# Altium schematic sheet Power.SchDoc
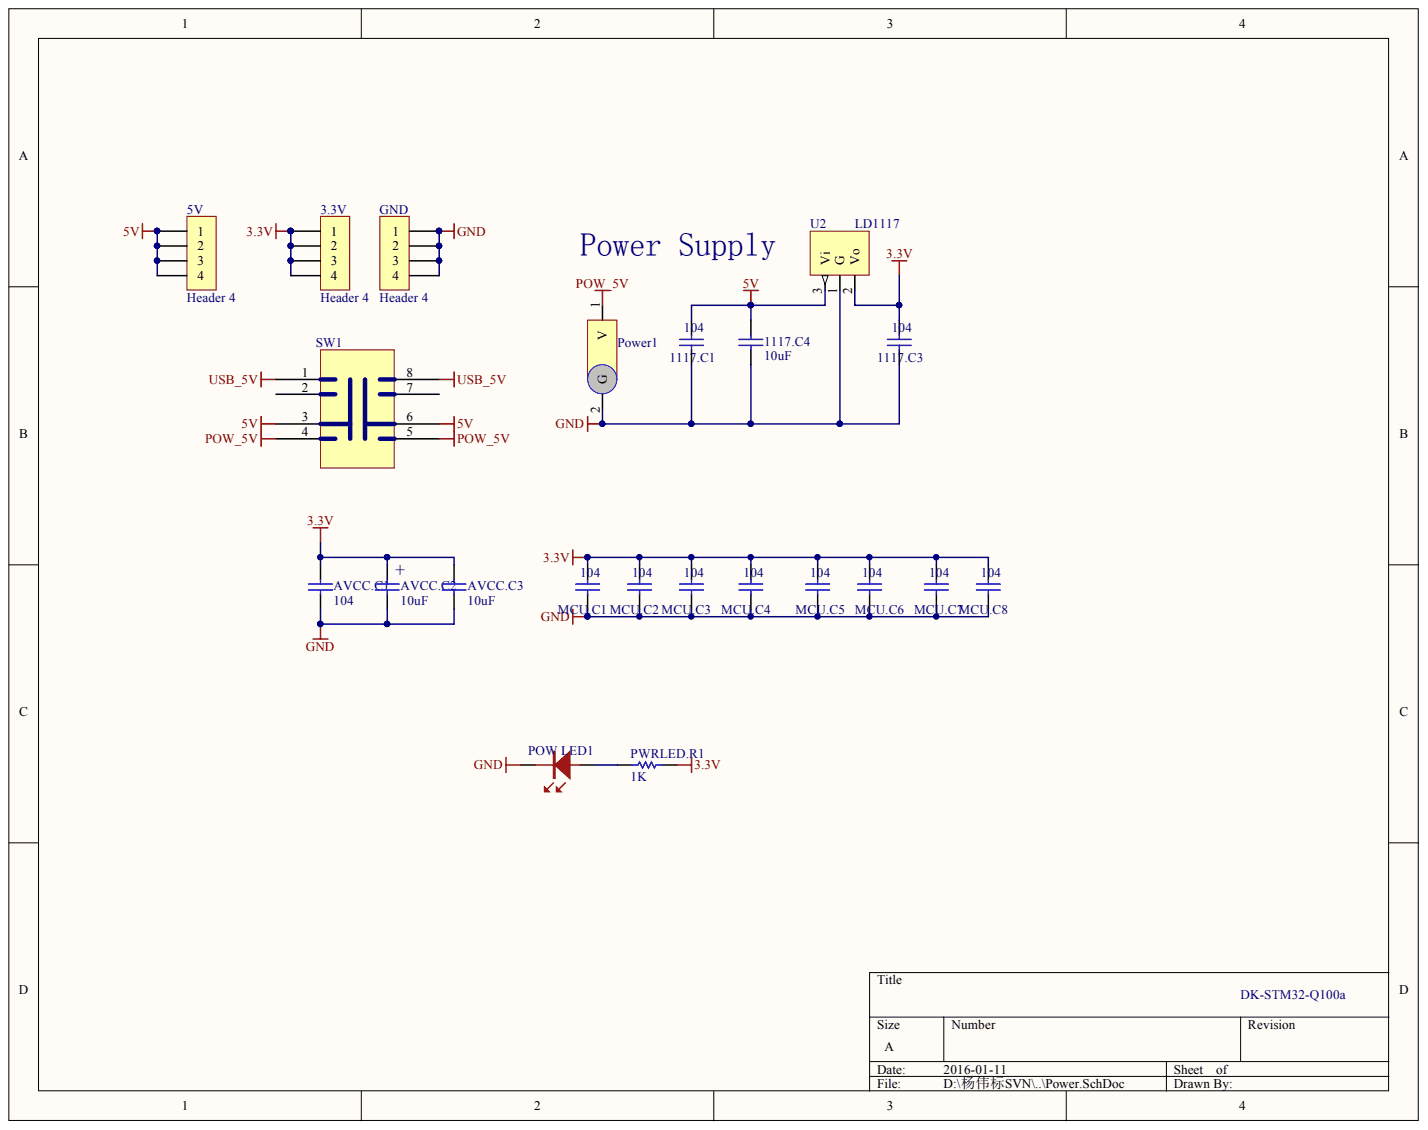
[diagram p0: Power.SchDoc]

## Parts
3.3V  Header 4  [SIP4]
5V  Header 4  [SIP4]
1117.C1  104  [0603]
1117.C3  104  [0603]
1117.C4  10uF  [ws_3225_1]
AVCC.C1  104  [0603]
AVCC.C2  10uF  [ws_3225_1]
AVCC.C3  10uF  [ws_3225_1]
GND  Header 4  [SIP4]
MCU.C1  104  [0603]
MCU.C2  104  [0603]
MCU.C3  104  [0603]
MCU.C4  104  [0603]
MCU.C5  104  [0603]
MCU.C6  104  [0603]
MCU.C7  104  [0603]
MCU.C8  104  [0603]
POW_LED1  LED_0805  [0805_LED]  (value not drawn)
Power1  5V  [PWR_5V]  (value not drawn)
PWRLED.R1  1K  [0603]
SW1  (no value)  [Switch3-1]
U2  LD1117  [SOT-223]

## Nets
3.3V: 3.3V.1 3.3V.2 3.3V.3 3.3V.4 1117.C3.2 AVCC.C1.1 AVCC.C2.1 AVCC.C3.1 MCU.C1.2 MCU.C2.2 MCU.C3.2 MCU.C4.2 MCU.C5.2 MCU.C6.2 MCU.C7.2 MCU.C8.2 PWRLED.R1.1 U2.2
5V: 5V.1 5V.2 5V.3 5V.4 1117.C1.1 1117.C4.1 SW1.3 SW1.6 U2.3
GND: 1117.C1.2 1117.C3.1 1117.C4.2 AVCC.C1.2 AVCC.C2.2 AVCC.C3.2 GND.1 GND.2 GND.3 GND.4 MCU.C1.1 MCU.C2.1 MCU.C3.1 MCU.C4.1 MCU.C5.1 MCU.C6.1 MCU.C7.1 MCU.C8.1 POW_LED1.2 Power1.2 U2.1
POW_5V: Power1.1 SW1.4 SW1.5
USB_5V: SW1.1 SW1.8
NetPOW LED1_1: POW_LED1.1 PWRLED.R1.2

Not connected: SW1 2 7

## Library data of the parts
3.3V 5V GND = Header 4: Header, 4-Pin; Component Kind: Standard; LatestRevisionDate: 17-Jul-2002; LatestRevisionNote: Re-released for DXP Platform.; Library Name: zz.SchLib; Publisher: Altium Limited
1117.C1 1117.C3 1117.C4 AVCC.C1-AVCC.C3 MCU.C1-MCU.C8 = C_0805: Capacitor; Component Kind: Standard; Library Name: zz.SchLib
  1117.C1 1117.C3 MCU.C1-MCU.C8: Value: 104
  1117.C4 AVCC.C2 AVCC.C3: Value: 10uF
Power1 = Power5: Component Kind: Standard; Description: Power5; Library Name: zz.SchLib
PWRLED.R1 = R_0805: Resistor; Component Kind: Standard; Library Name: zz.SchLib; Value: 1K
SW1 = switch3-1: Component Kind: Standard; Library Name: zz.SchLib
POW_LED1 = LED_0805: Component Kind: Standard; Library Name: zz.SchLib
U2 = LD1117: Component Kind: Standard; Library Name: zz.SchLib
